# Altium schematic sheet F133.SchDoc(F133)
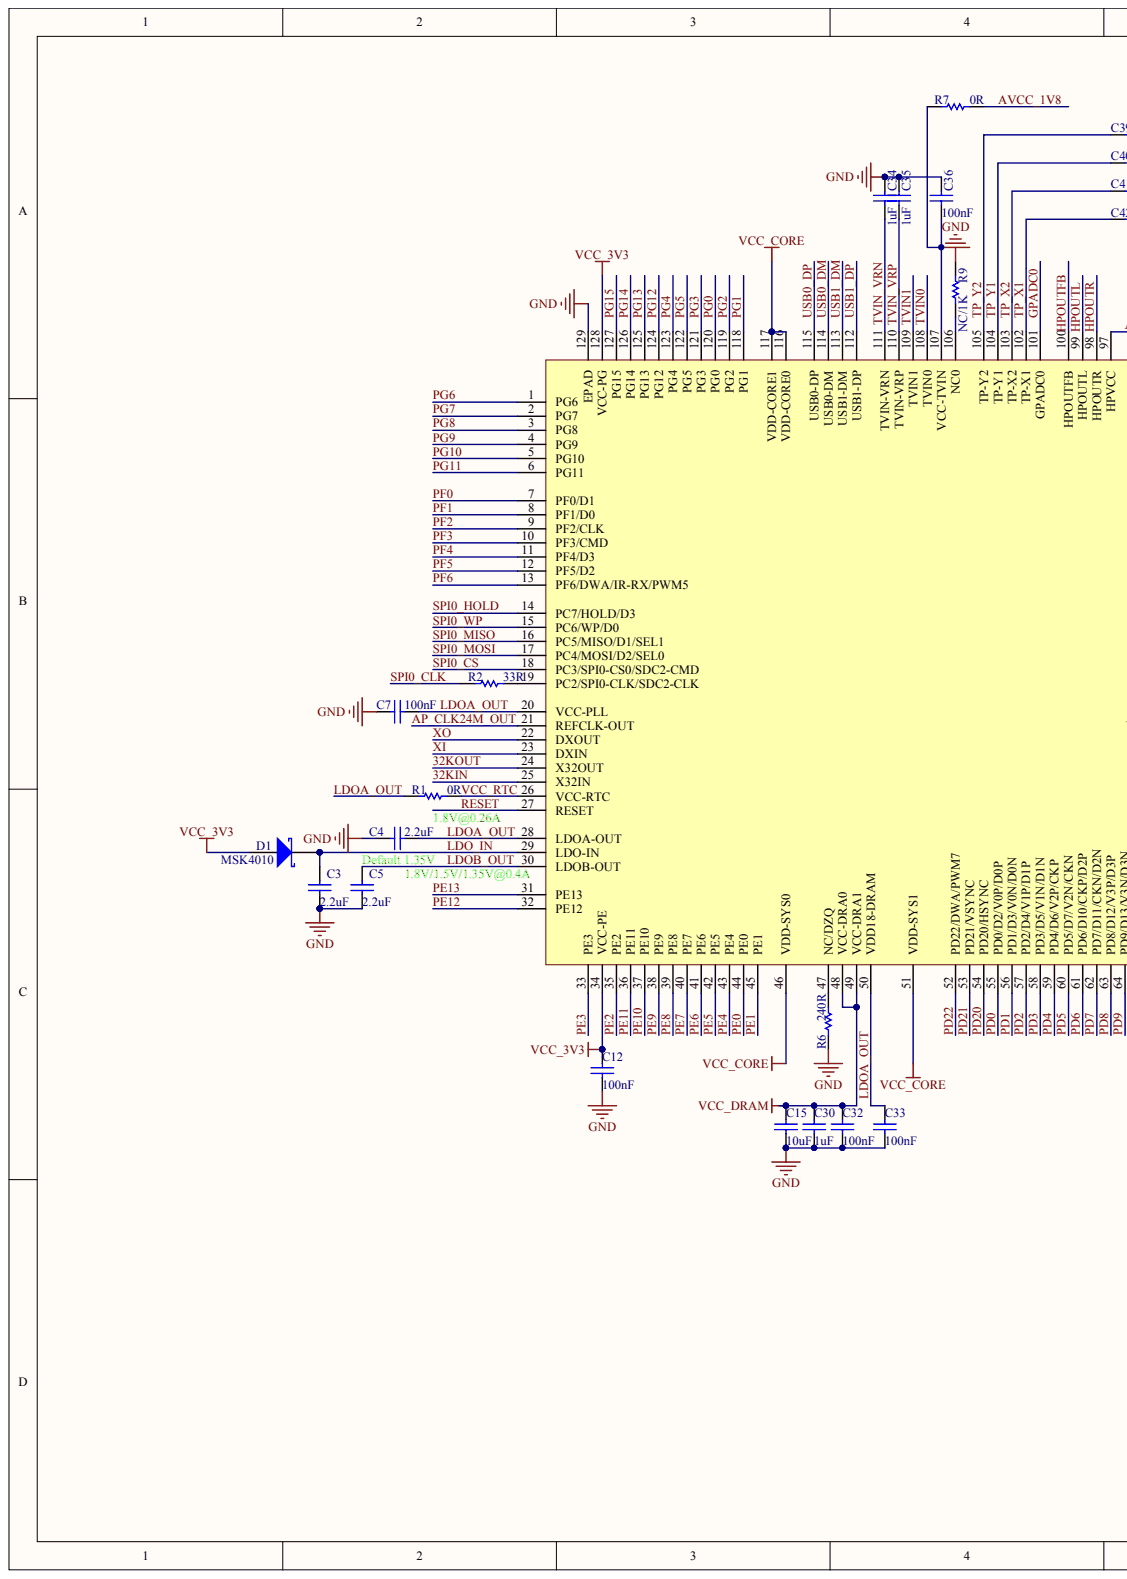
[diagram p0: F133.SchDoc, left]
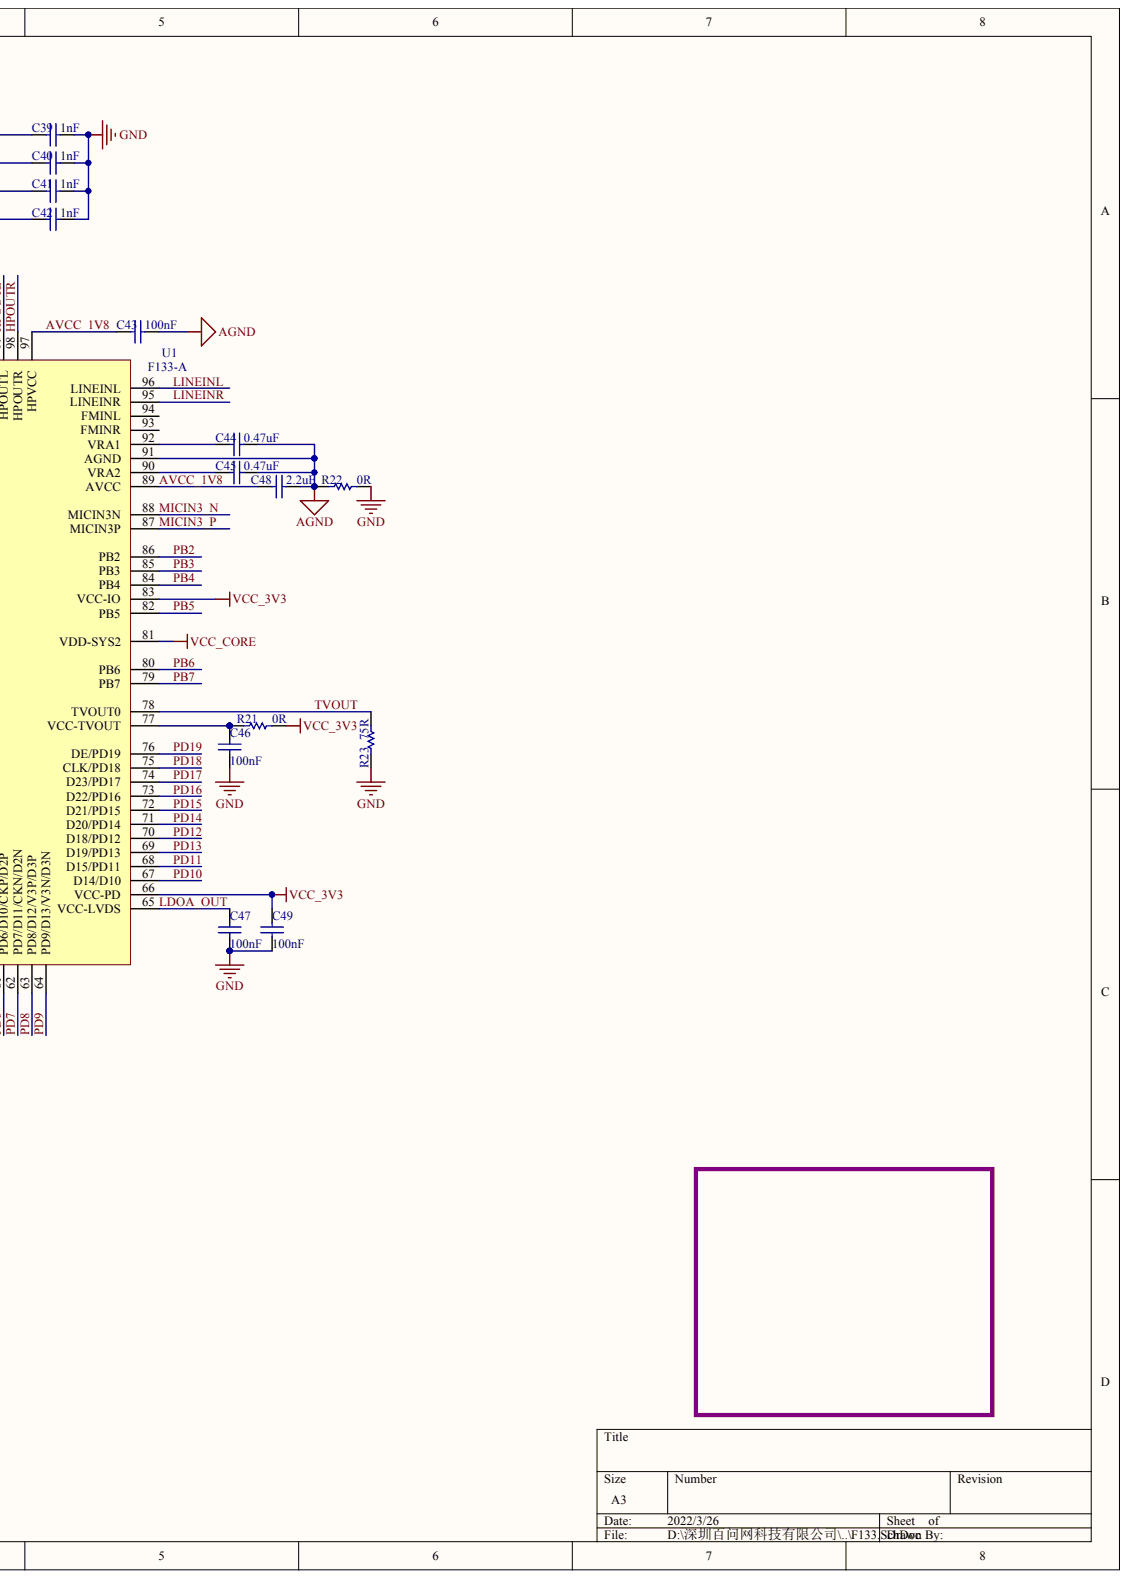
[diagram p1: F133.SchDoc, right]

## Parts
C3  2.2uF  (value not drawn)
C4  2.2uF
C5  2.2uF  (value not drawn)
C7  100nF
C12  100nF
C15  10uF  (value not drawn)
C30  1uF
C32  100nF
C33  100nF
C34  1uF
C35  1uF
C36  100nF
C39  1nF
C40  1nF
C41  1nF
C42  1nF
C43  100nF
C44  0.47uF
C45  0.47uF
C46  100nF
C47  100nF
C48  2.2uF
C49  100nF
C50  1nF  (value not drawn)
C51  100nF  (value not drawn)
C52  10uF  (value not drawn)
C53  100pF  (value not drawn)
C54  100nF  (value not drawn)
C55  100nF  (value not drawn)
C57  20pF  (value not drawn)
C58  20pF  (value not drawn)
C59  10uF  (value not drawn)
C60
C61  20pF  (value not drawn)
C62  100nF  (value not drawn)
C63  100nF  (value not drawn)
C64  100nF  (value not drawn)
C65  100nF  (value not drawn)
C66
C67  100nF  (value not drawn)
C68  100nF  (value not drawn)
C69  100nF  (value not drawn)
C70  100nF  (value not drawn)
C71  20pF  (value not drawn)
D1  MSK4010
R1  0R
R2  33R
R6  240R
R7  0R
R9  NC/1K
R21  0R
R22  0R
R23  75R
R24  100K  (value not drawn)
R25  10K  (value not drawn)
R29  75R  (value not drawn)
R30  1K  (value not drawn)
R31  1K  (value not drawn)
R34  680K  (value not drawn)
R37  33R  (value not drawn)
R38  33R  (value not drawn)
U1  F133-A
X1  24MHz  (value not drawn)
X2  32.768K  (value not drawn)

## Nets
AGND: C43.2 C44.2 C45.2 C48.2 C50.2 C52.1 R22.2 R31.2 R37.1 R38.1 U1.91
GND: C3.2 C4.1 C5.2 C7.1 C12.2 C15.2 C30.2 C32.2 C33.2 C34.1 C35.1 C36.2 C39.1 C40.1 C41.1 C42.1 C46.2 C47.2 C49.2 C51.2 C57.1 C58.1 C59.2 C60.2 C61.2 C62.2 C63.2 C64.2 C65.2 C66.2 C67.2 C70.2 C71.2 R6.1 R9.1 R22.1 R23.1 U1.129 X1.2 X1.4
VCC_3V3: C12.1 C49.1 C59.1 C62.1 C64.1 C66.1 D1.1 R21.1 U1.34 U1.66 U1.83 U1.128
VCC_CORE: C60.1 C63.1 C65.1 C67.1 C70.1 U1.46 U1.51 U1.81 U1.116 U1.117
VCC_DRAM: C15.1 C30.1 C32.1 U1.48 U1.49
32KIN: C58.2 R34.2 U1.25 X2.1
32KOUT: C57.2 R34.1 U1.24 X2.2
AP_CLK24M_OUT: U1.21
AVCC_1V8: C43.1 C48.1 R7.1 R24.1 R29.1 U1.89 U1.97
GPADC0: C50.1 R24.2 U1.101
HPOUTFB: U1.100
HPOUTL: C68.1 U1.99
HPOUTR: C69.1 U1.98
LDO_IN: C3.1 D1.2 U1.29
LDOA_OUT: C4.2 C7.2 C33.1 C47.1 R1.2 R25.2 U1.20 U1.28 U1.50 U1.65
LDOB_OUT: C5.1 U1.30
LINEINL: U1.96
LINEINR: U1.95
MICIN3_N: C55.2 U1.88
MICIN3_P: C54.2 U1.87
MICIN_N: C53.2 C55.1 R31.1
MICIN_P: C53.1 C54.1 R30.2
PB2: U1.86
PB3: U1.85
PB4: U1.84
PB5: U1.82
PB6: U1.80
PB7: U1.79
PD0: U1.55
PD1: U1.56
PD2: U1.57
PD3: U1.58
PD4: U1.59
PD5: U1.60
PD6: U1.61
PD7: U1.62
PD8: U1.63
PD9: U1.64
PD10: U1.67
PD11: U1.68
PD12: U1.70
PD13: U1.69
PD14: U1.71
PD15: U1.72
PD16: U1.73
PD17: U1.74
PD18: U1.75
PD19: U1.76
PD20: U1.54
PD21: U1.53
PD22: U1.52
PE0: U1.44
PE1: U1.45
PE2: U1.35
PE3: U1.33
PE4: U1.43
PE5: U1.42
PE6: U1.41
PE7: U1.40
PE8: U1.39
PE9: U1.38
PE10: U1.37
PE11: U1.36
PE12: U1.32
PE13: U1.31
PF0: U1.7
PF1: U1.8
PF2: U1.9
PF3: U1.10
PF4: U1.11
PF5: U1.12
PF6: U1.13
PG0: U1.120
PG1: U1.118
PG2: U1.119
PG3: U1.121
PG4: U1.123
PG5: U1.122
PG6: U1.1
PG7: U1.2
PG8: U1.3
PG9: U1.4
PG10: U1.5
PG11: U1.6
PG12: U1.124
PG13: U1.125
PG14: U1.126
PG15: U1.127
RESET: C51.1 R25.1 U1.27
SPI0_CLK: R2.2
SPI0_CS: U1.18
SPI0_HOLD: U1.14
SPI0_MISO: U1.16
SPI0_MOSI: U1.17
SPI0_WP: U1.15
TP_X1: C42.2 U1.102
TP_X2: C41.2 U1.103
TP_Y1: C40.2 U1.104
TP_Y2: C39.2 U1.105
TVIN0: U1.108
TVIN1: U1.109
TVIN_VRN: C34.2 U1.111
TVIN_VRP: C35.2 U1.110
TVOUT: R23.2 U1.78
USB0_DM: U1.114
USB0_DP: U1.115
USB1_DM: U1.113
USB1_DP: U1.112
VCC_RTC: R1.1 U1.26
XI: C61.1 U1.23 X1.1
XO: C71.1 U1.22 X1.3
NetC36_1: C36.1 R7.2 U1.107
NetC44_1: C44.1 U1.92
NetC45_1: C45.1 U1.90
NetC46_1: C46.1 R21.2 U1.77
NetC52_2: C52.2 R29.2 R30.1
NetC68_2: C68.2 R37.2
NetC69_2: C69.2 R38.2
NetR2_1: R2.1 U1.19
NetR6_2: R6.2 U1.47
NetR9_2: R9.2 U1.106

Not connected: U1 93 94

## Library data of the parts
C3-C5 C7 C12 C15 C30 C32-C36 C39-C55 C57-C59 C61-C65 C67-C71 = (no symbol name): PartNumber: CAP100NF; Published: 8-Jun-2000; LatestRevisionDate: 17-Jul-2002; LatestRevisionNote: Re-released for DXP Platform.; PackageReference: 0402; Publisher: Altium Limited
  C3-C5 C48: Value: 2.2uF
  C7 C12 C32 C33 C36 C43 C46 C47 C49 C51 C54 C55 C62-C65 C67-C70: Value: 100nF
  C15 C52 C59: Value: 10uF
  C30 C34 C35: Value: 1uF
  C39-C42 C50: Value: 1nF
  C44 C45: Value: 0.47uF
  C53: Value: 100pF
  C57 C58 C61 C71: Value: 20pF
R1 R2 R6 R7 R9 R21-R25 R29-R31 R34 R37 R38 = (no symbol name): PartNumber: RES2.2K; Published: 8-Jun-2000; LatestRevisionDate: 17-Jul-2002; LatestRevisionNote: Re-released for DXP Platform.; PackageReference: 0402; Publisher: Altium Limited
  R1 R7 R21 R22: Value: 0R
  R2 R37 R38: Value: 33R
  R6: Value: 240R
  R9: Value: NC/1K
  R23 R29: Value: 75R
  R24: Value: 100K
  R25: Value: 10K
  R30 R31: Value: 1K
  R34: Value: 680K
X1 = (no symbol name): BOM_Manufacturer: JGHC; BOM_Manufacturer Part: X252024MPB4SC; nameAlias: Frequency; Contributor: LCSC; BOM_Supplier: LCSC; BOM_Supplier Part: C65961; spicePre: X; spiceSymbolName: X252024MPB4SC
X2 = (no symbol name): BOM_Manufacturer Part: TFX-03C-32.768K; Contributor: LCSC; nameAlias: Frequency; BOM_Supplier: LCSC; BOM_Supplier Part: C515344; BOM_JLCPCB Part Class: Extended Part; spicePre: X; spiceSymbolName: TFX-03C-32.768K
D1 U1 = (no symbol name)
  D1: Published: 8-Jun-2000; LatestRevisionDate: 17-Jul-2002; LatestRevisionNote: Re-released for DXP Platform.; PackageReference: SMB; PackageDescription: DO-214-AA/SMB; 2 C-Bend Leads; Body 5.3 x 3.6 mm, inc. leads (LxW); Code_JEDEC: DO-214-AA; Publisher: Altium Limited
